# Altium schematic sheet main.SchDoc(main)
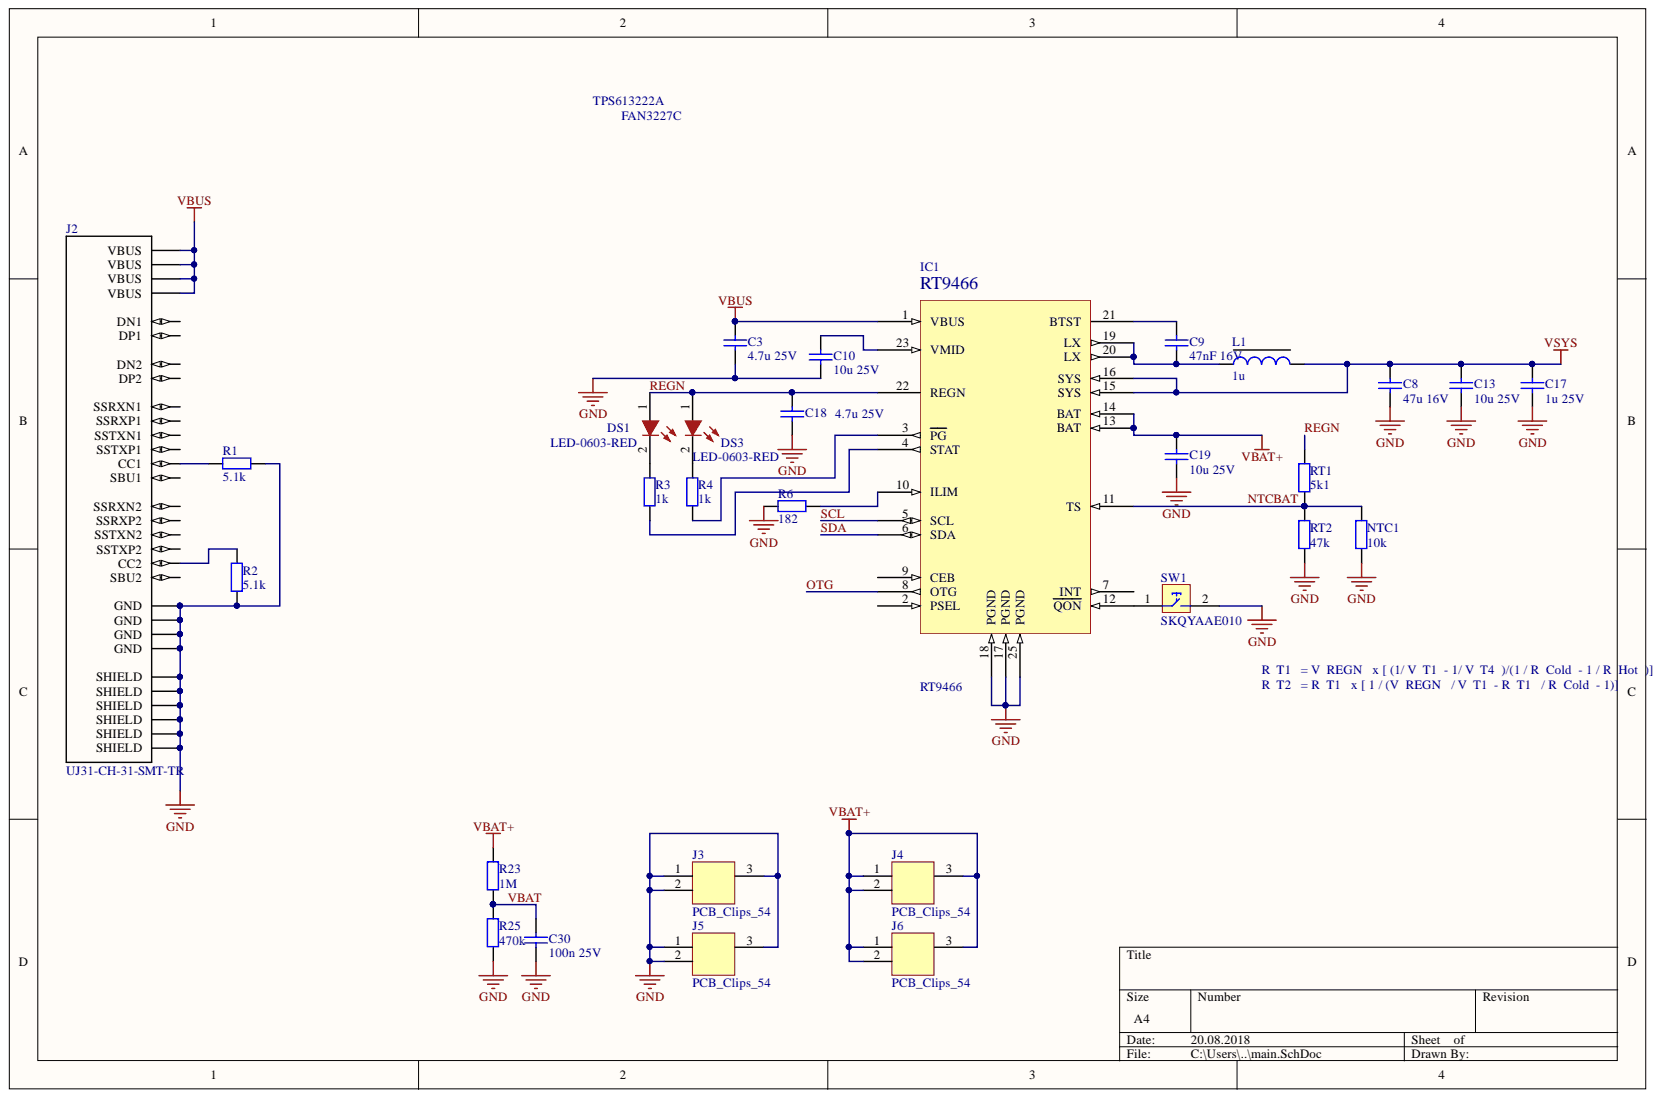
[diagram p0: main.SchDoc]

## Parts
C3  4.7u 25V  [C-0805-H]
C8  47u 16V  [C-1210-M]
C9  47nF 16V  [C-0603-M]
C10  10u 25V  [C-0805-H]
C13  10u 25V  [C-0805-H]
C17  1u 25V  [C-0805-H]
C18  4.7u 25V  [C-0805-H]
C19  10u 25V  [C-0805-H]
C30  100n 25V  [C-0603-H]
DS1  LED-0603-RED  [LED-0603-RED]
DS3  LED-0603-RED  [LED-0603-RED]
IC1  RT9466  [RT9466]
J2  UJ31-CH-31-SMT-TR  [CUI_UJ31-CH-31-SMT-TR_UJ31-CH-31-SMT-TR(Primary)]
J3  PCB_Clips_54  [KEYSTONE-CLIPS_54-3_V]
J4  PCB_Clips_54  [KEYSTONE-CLIPS_54-3_V]
J5  PCB_Clips_54  [KEYSTONE-CLIPS_54-3_V]
J6  PCB_Clips_54  [KEYSTONE-CLIPS_54-3_V]
L1  1u  [LPS4012]
NTC1  10k  [R-0603-H]
R1  5.1k  [R-0603-H]
R2  5.1k  [R-0603-H]
R3  1k  [R-0603-M]
R4  1k  [R-0603-M]
R6  182  [R-0603-M]
R23  1M  [R-0603-H]
R25  470k  [R-0603-H]
RT1  5k1  [R-0603-H]
RT2  47k  [R-0603-H]
SW1  SKQYAAE010  [switch-mini-ALPS]

## Nets
GND: C3.2 C8.1 C10.1 C13.1 C17.1 C18.1 C19.1 C30.1 IC1.17(PGND) IC1.18(PGND) IC1.25(PGND) J2.A1(GND) J2.A12(GND) J2.B1(GND) J2.B12(GND) J2.SH1(SHIELD) J2.SH2(SHIELD) J2.SH3(SHIELD) J2.SH4(SHIELD) J2.SH5(SHIELD) J2.SH6(SHIELD) J3.1 J3.2 J3.3 J5.1 J5.2 J5.3 NTC1.1 R1.1 R2.1 R6.1 R25.1 RT2.1 SW1.2
VBAT+: C19.2 IC1.13(BAT) IC1.14(BAT) J4.1 J4.2 J4.3 J6.1 J6.2 J6.3 R23.2
VBUS: C3.1 IC1.1(VBUS) J2.A4(VBUS) J2.A9(VBUS) J2.B4(VBUS) J2.B9(VBUS)
VSYS: C8.2 C13.2 C17.2 IC1.15(SYS) IC1.16(SYS) L1.2
NTCBAT: IC1.11(TS) NTC1.2 RT1.1 RT2.2
OTG: IC1.8(OTG)
REGN: C18.2 DS1.1 DS3.1 IC1.22(REGN) RT1.2
SCL: IC1.5(SCL)
SDA: IC1.6(SDA)
VBAT: C30.2 R23.1 R25.2
NetC9_1: C9.1 IC1.19(LX) IC1.20(LX) L1.1
NetC9_2: C9.2 IC1.21(BTST)
NetC10_2: C10.2 IC1.23(VMID)
NetDS1_2: DS1.2 R3.1
NetDS3_2: DS3.2 R4.1
NetIC1_3: IC1.3(PG) R4.2
NetIC1_4: IC1.4(STAT) R3.2
NetIC1_10: IC1.10(ILIM) R6.2
NetIC1_12: IC1.12(QON) SW1.1
NetJ2_A5: J2.A5(CC1) R1.2
NetJ2_B5: J2.B5(CC2) R2.2

Not connected: IC1 2(PSEL) 7(INT) 9(CEB); J2 A2(SSTXP1) A3(SSTXN1) A6(DP1) A7(DN1) A8(SBU1) A10(SSRXN2) A11(SSRXP2) B2(SSTXP2) B3(SSTXN2) B6(DP2) B7(DN2) B8(SBU2) B10(SSRXN1) B11(SSRXP1)

## Library data of the parts
C3 C18 = C-0805-4u7-25V: Capacitor 4.7u 25V; Supplier Part Number 1: 80-C0805C475K3P; Supplier 1: Mouser; Library Name: Capacitors-0805.SchLib; Component Kind: Standard
C8 = C-1210-47u-16V: Capacitor 47uF 16V; Supplier Part Number 1: 80-C1210C476M4P; Supplier 1: Mouser; Library Name: Capacitors-1210.SchLib; Component Kind: Standard
C9 = C-0603-47n-16V: Capacitor 47nF 16V; Library Name: Capacitors-0603.SchLib; Component Kind: Standard
C10 C13 C17 C19 = C-0805-10u-25V: Capacitor 10u 25V; Supplier Part Number 1: 80-C0805C106K3P; Supplier 1: Mouser; Library Name: Capacitors-0805.SchLib; Component Kind: Standard
C30 = C-0603-100n-25V: Capacitor 100n 25V; Supplier Part Number 1: 80-C0603C104K3R; Supplier 1: Mouser; Library Name: Capacitors-0603.SchLib; Component Kind: Standard
J2 = UJ31-CH-31-SMT-TR: Conn USB 3.1 Type C RCP 24 POS 0.5mm Solder RA SMD 24 Terminal 1 Port T/R; MF: CUI; SnapEDA_Link: https://www.snapeda.com/parts/UJ31-CH-31-SMT-TR/CUI/view-part/?ref=snap; Price: 1.40 USD; Package: None; MP: UJ31-CH-31-SMT-TR; Library Name: UJ31-CH-31-SMT-TR_1.IntLib; Component Kind: Standard; Availability: Good
J3-J6 = CMP-0466-00001-2: PC Battery Clip, Catalog No.54, Spring Steel, Body 18.102 x 13.004 mm, THM; Manufacturer: Keystone; Finish/Plating: Tin-Nickel; Cell Size: 18650; # of Cells: 1; Type: CLIP; PartNumber: PCB_Clips_54; PackageReference: KEYSTONE-CLIPS_54-3; PackageDescription: 3-Pin Hand Drawn, Body 18.102 x 13.004 mm; Mounting Technology: Through Hole; Material: Spring Steel; Library Name: Altium Content Vault; ComponentLink2URL: http://www.keyelco.com/userAssets/file/M60-2p9.pdf; ComponentLink2Description: Datasheet; ComponentLink1URL: http://www.keyelco.com/; ComponentLink1Description: Manufacturer URL; Component Kind: Standard
L1 = L-4u7-LPS4012-472MRB: LPS4012-472MRB; Supplier Part Number 1: 994-LPS4012-472MRB; Supplier 1: Mouser; Library Name: Inductors.SchLib; Component Kind: Standard
NTC1 = R-0603-10k: NTC 10k; Supplier Part Number 1: 71-CRCW0603-10K-E3; Supplier 1: Mouser; Library Name: Resistors-0603.SchLib; Component Kind: Standard
R1 R2 = R-0603-5k1: Resistor 5.1k; Supplier Part Number 1: 71-CRCW0603-5.1K-E3; Supplier 1: Mouser; Library Name: Resistors-0603.SchLib; Component Kind: Standard
R3 R4 = R-0603-1k5: Resistor 1.5k; Supplier Part Number 1: 71-CRCW0603-1.5K-E3; Supplier 1: Mouser; Library Name: Resistors-0603.SchLib; Component Kind: Standard
R6 = R-0603-698: Resistor 698Ohm; Resistance: 0; Supplier 1: Mouser; Library Name: Resistors-0603.SchLib; Component Kind: Standard
R23 = R-0603-47k: Resistor 10k; Supplier Part Number 1: 71-CRCW060347K0FKEB; Supplier 1: Mouser; Library Name: Resistors-0603.SchLib; Component Kind: Standard
R25 = R-0603-4k7: Resistor 4k7; Supplier Part Number 1: 71-CRCW0603-4.7K-E3; Supplier 1: Mouser; Library Name: Resistors-0603.SchLib; Component Kind: Standard
RT1 RT2 = R-0603-10k: Resistor 10k; Supplier Part Number 1: 71-CRCW0603-10K-E3; Supplier 1: Mouser; Library Name: Resistors-0603.SchLib; Component Kind: Standard
SW1 = Switch-mini-ALPS: Supplier Part Number 1: 688-SKQYAA; Supplier 1: Mouser; Library Name: advanced.SchLib; Description: SKQYAAE010; Component Kind: Standard
DS1 DS3 = LED-0603-RED: Supplier Part Number 1: 859-LTST-C190EKT; Supplier 1: Mouser; Library Name: advanced.SchLib; Component Kind: Standard
IC1 = RT9466: Library Name: advanced.SchLib; Component Kind: Standard
